# Altium schematic sheet AudioWayDSP-Power.SchDoc
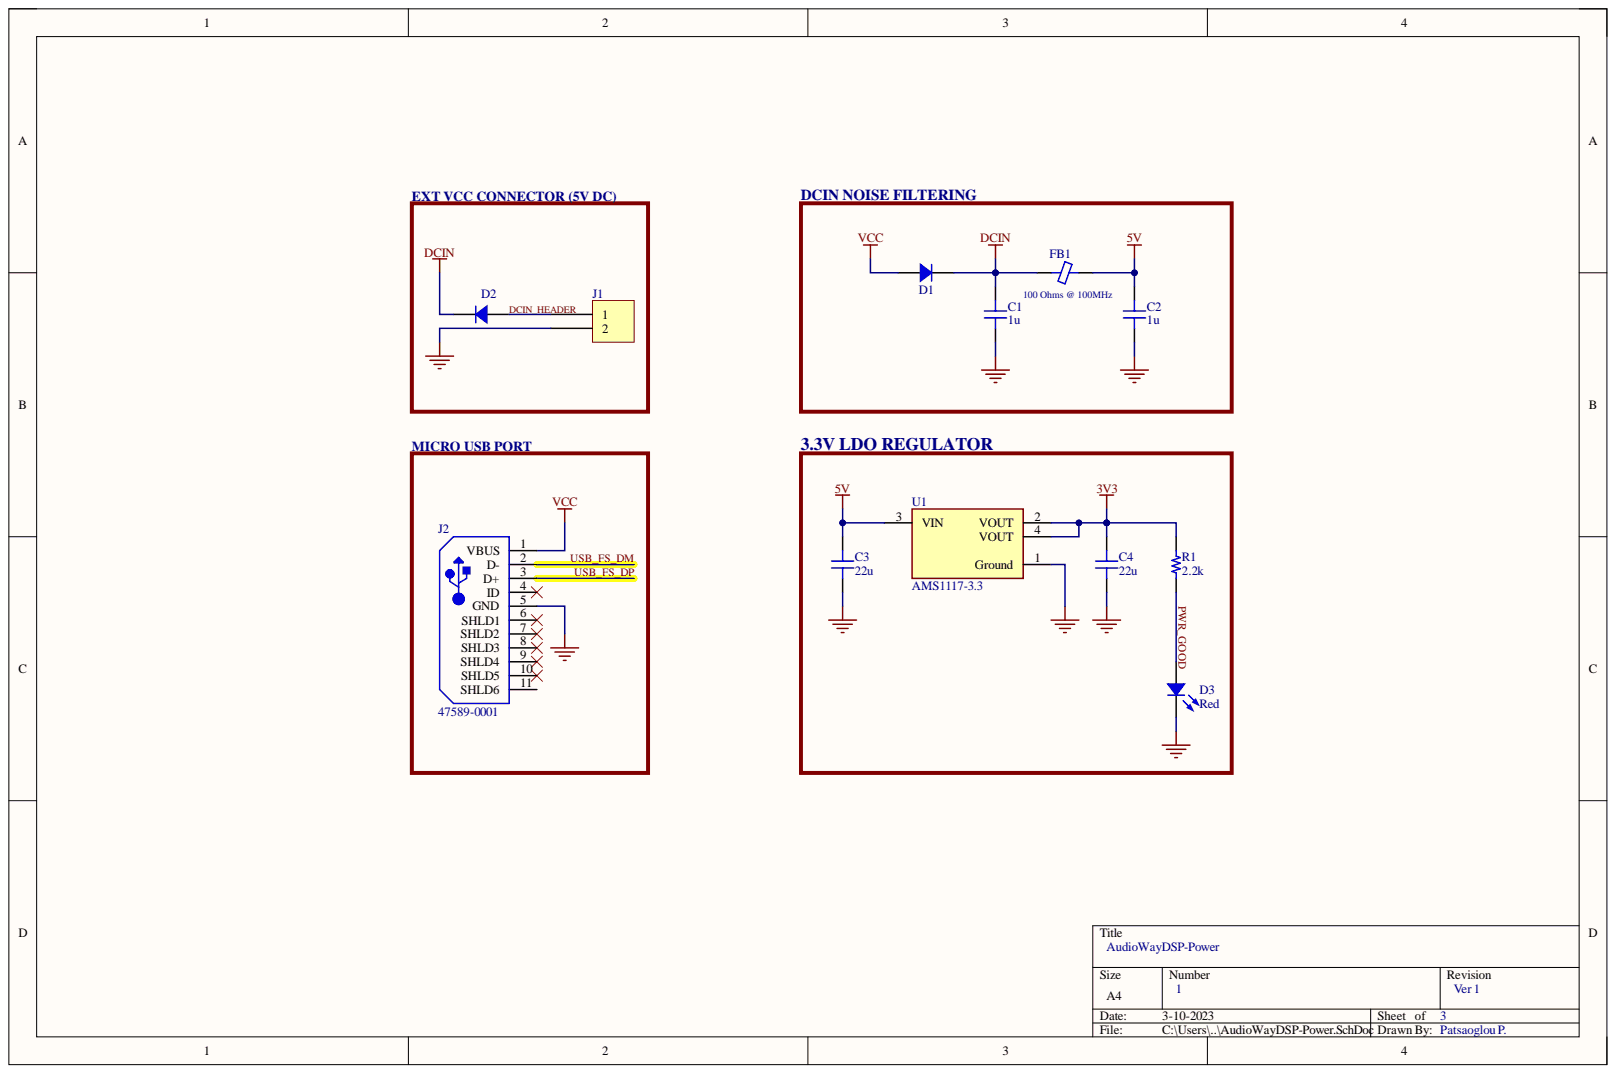
[diagram p0: AudioWayDSP-Power.SchDoc]

## Parts
C1  1u  [CAP 0603_1608]
C2  1u  [CAP 0603_1608]
C3  22u  [CAP 0805_2012]
C4  22u  [CAP 0805_2012]
D1  PMEG4010BEA,115  [SOD323]  (value not drawn)
D2  PMEG4010BEA,115  [SOD323]  (value not drawn)
D3  Red  [LED 0603_1608 RED]
FB1  100 Ohms @ 100MHz  [FER 0805_2012]
J1  B2B-XH-A(LF)(SN)  [JST XH B2B-XH-A]  (value not drawn)
J2  47589-0001  [MOLX-47589-0001_V]
R1  2.2k  [RES 0402_1005]
U1  AMS1117-3.3  [FP-AMS1117-IPC_C]

## Nets
3V3: C4.2 R1.1 U1.2(VOUT) U1.4(VOUT)
5V: C2.2 C3.2 FB1.2 U1.3(VIN)
DCIN: C1.2 D1.2 D2.2 FB1.1
GND: C1.1 C2.1 C3.1 C4.1 D3.2 J1.2 J2.5(GND) U1.1(Ground)
VCC: D1.1 J2.1(VBUS)
DCIN_HEADER: D2.1 J1.1
PWR_GOOD: D3.1 R1.2
USB_FS_DM: J2.2(D-)
USB_FS_DP: J2.3(D+)

Not connected: J2 4(ID) 6(SHLD1) 7(SHLD2) 8(SHLD3) 9(SHLD4) 10(SHLD5) 11(SHLD6)

## Library data of the parts
C1 C2 = 06035D105KAT2A: CAP CER 1UF 50V X5R 0603; manufacturer: AVX Corporation; Capacitance: 1µF; Database Table Name: Capacitors - Ceramic - 0603; Tolerance: ±10%; Applications: General Purpose; Component Kind: Standard; componentlink1description: Datasheet; componentlink1url: http://datasheets.avx.com/cx5r.pdf; componentlink2description: DigiKey Link; componentlink2url: http://digikey.com/product-detail/en/avx-corporation/06035D105KAT2A/478-10067-1-ND/6564289; Device Package: 0603 (1608 Metric); lastupdated: 02-Sep-20 1:03:26 AM; Library Name: Celestial Altium Library - altium_library.DbLib; Lifecycle Status: Active; Minimum Order: 1; Mounting Type: Surface Mount, MLCC; Operating Temperature: -55°C ~ 85°C; Package / Case: 0603 (1608 Metric); packaging: TapeAndReel; Part Number: 06035D105KAT2A; Part Status: Active; partid: 7730; price: 0.15; series: -; Size / Dimension: 0.063" L x 0.032" W (1.60mm x 0.81mm); Supplier 1: DigiKey; Supplier Part Number 1: 478-10067-1-ND; Temperature Coefficient: X5R; Thickness (Max): 0.035" (0.90mm); Value: 1u; Voltage - Rated: 50V
C3 C4 = TMK212BBJ226MG-TT: CAP CER 22UF 25V X5R 0805; manufacturer: Taiyo Yuden; Capacitance: 22 µF; Database Table Name: Capacitors - Ceramic - 0805; Tolerance: ±20%; Applications: SMPS Filtering; Component Kind: Standard; componentlink1description: Datasheet; componentlink1url: https://ds.yuden.co.jp/TYCOMPAS/ut/detail?pn=TMK212BBJ226MG-TT &u=M; componentlink2description: DigiKey Link; componentlink2url: https://www.digikey.com/en/products/detail/taiyo-yuden/TMK212BBJ226MG-TT/7403956; Device Package: 0805 (2012 Metric); lastupdated: 01-Mar-23 8:10:58 PM; Library Name: Celestial Altium Library - altium_library.DbLib; Lifecycle Status: Active; Minimum Order: 1; Mounting Type: Surface Mount, MLCC; Operating Temperature: -55°C ~ 85°C; Package / Case: 0805 (2012 Metric); packaging: TapeAndReel; Part Number: TMK212BBJ226MG-TT; Part Status: Active; partid: 8285; price: 0.16; series: M; Size / Dimension: 0.079" L x 0.049" W (2.00mm x 1.25mm); Supplier 1: DigiKey; Supplier Part Number 1: 587-6359-1-ND; Temperature Coefficient: X5R; Thickness (Max): 0.057" (1.45mm); Value: 22u; Voltage - Rated: 25V
D1 D2 = PMEG4010BEA,115: DIODE SCHOTTKY 40V 1A SOD323; Manufacturer: Nexperia USA Inc.; Capacitance @ Vr, F: 50pF @ 1V, 1MHz; Current - Reverse Leakage @ Vr: 100 µA @ 40 V; Database Table Name: Diodes - Rectifiers - Single; Speed: Fast Recovery =< 500ns, > 200mA (Io); Voltage - Forward (Vf) (Max) @ If: 640 mV @ 1 A; Component Kind: Standard; ComponentLink1Description: Datasheet; ComponentLink1URL: https://assets.nexperia.com/documents/data-sheet/PMEGXX10BEA_PMEGXX10BEV.pdf; ComponentLink2Description: DigiKey Link; ComponentLink2URL: https://www.digikey.com/en/products/detail/nexperia-usa-inc/PMEG4010BEA-115/1157708; Current - Average Rectified (Io): 1A; Diode Type: Schottky; LastUpdated: 01-Mar-23 9:08:18 PM; Library Name: Celestial Altium Library - altium_library.DbLib; Lifecycle Status: Active; Minimum Order: 1; Mounting Type: Surface Mount; Operating Temperature - Junction: 150°C (Max); Package / Case: SC-76, SOD-323; Packaging: TapeAndReel; Part Number: PMEG4010BEA,115; Part Status: Active; PartId: 3088; Price: 0.07; Series: -; Supplier 1: DigiKey; Supplier Device Package: SOD-323; Supplier Part Number 1: 1727-5211-1-ND; Voltage - DC Reverse (Vr) (Max): 40 V
D3 = 5973005507F: LED RED 0603 SMD; Manufacturer: Dialight; Configuration: Standard; Current - Test: 20mA; Database Table Name: LED - Indication; Lens Color: 0.68mm; Lens Size: 1.20mm x 0.80mm; Lens Style: Rectangle with Flat Top; Millicandela Rating: 90mcd; Voltage - Forward (Vf) (Typ): 2V; Wavelength - Dominant: 630nm; Color: Red; Component Kind: Standard; ComponentLink1Description: Datasheet; ComponentLink1URL: http://www.dialightsignalsandcomponents.com/Assets/Drawings/2D_Drawings_DrawingDetailedSpec/NewDrawings/18369A.pdf; ComponentLink2Description: DigiKey Link; ComponentLink2URL: https://www.digikey.com/en/products/detail/dialight/5973005507F/9385429; Device Package: 0603 (1608 Metric); Height (Max): 0.68mm; LastUpdated: 01-Mar-23 8:56:29 PM; Library Name: Celestial Altium Library - altium_library.DbLib; Lifecycle Status: Active; Minimum Order: 1; Mounting Type: Surface Mount; Package / Case: 0603 (1608 Metric); Packaging: TapeAndReel; Part Number: 5973005507F; Part Status: Active; PartId: 378; Price: 0.08; Series: 597; Size / Dimension: 1.60mm L x 0.80mm W; Supplier 1: DigiKey; Supplier Device Package: 0603; Supplier Part Number 1: 350-4374-1-ND; Value: Red
FB1 = Z0805C101ASMST: FERRITE BEAD 100 OHM 0805 1LN; Manufacturer: KEMET; Current Rating (Max): 900mA; Database Table Name: Filters - Ferrite Beads and Chips; DC Resistance (DCR) (Max): 100mOhm; Filter Type: Signal Line; Impedance @ Frequency: 600 Ohms @ 100MHz; Number of Lines: 1; Component Kind: Standard; ComponentLink1Description: Datasheet; ComponentLink1URL: https://content.kemet.com/datasheets/F3115.pdf; ComponentLink2Description: DigiKey Link; ComponentLink2URL: http://digikey.com/product-detail/en/kemet/Z0805C101ASMST/399-17791-1-ND/8601454; Device Package: 0805 (2012 Metric); Height (Max): 0.041" (1.05mm); LastUpdated: 01-Mar-23 8:50:40 PM; Library Name: Celestial Altium Library - altium_library.DbLib; Lifecycle Status: Active; Minimum Order: 1; Mounting Type: Surface Mount; Operating Temperature: -55°C ~ 125°C; Package / Case: 0805 (2012 Metric); Packaging: TapeAndReel; Part Number: Z0805C101ASMST; Part Status: Active; PartId: 1274; Price: 0.04; Series: Z-SMS; Size / Dimension: 0.079" L x 0.049" W (2.00mm x 1.25mm); Supplier 1: DigiKey; Supplier Part Number 1: 399-17791-1-ND; Value: 100 Ohms @ 100MHz
J1 = B2B-XH-A(LF)(SN): CONN HEADER VERT 2POS 2.5MM; Manufacturer: JST Sales America Inc.; Contact Length - Post: 0.134" (3.40mm); Current Rating (Amps): 3A; Database Table Name: Connectors - Rectangular Headers Male Pins; Material Flammability Rating: UL94 V-0; Number of Positions: 2; Number of Positions Loaded: All; Pitch - Mating: 0.098" (2.50mm); Shrouding: Shrouded - 4 Wall; Style: Board to Cable/Wire; Voltage Rating: 250V; Component Kind: Standard; ComponentLink1Description: Datasheet; ComponentLink1URL: http://www.jst-mfg.com/product/pdf/eng/eXH.pdf; ComponentLink2Description: DigiKey Link; ComponentLink2URL: http://digikey.com/product-detail/en/jst-sales-america-inc/B2B-XH-A-LF-SN/455-2247-ND/1651045; Connector Type: Header; Contact Finish - Mating: Tin; Contact Finish - Post: Tin; Contact Material: Brass; Contact Shape: Square; Contact Type: Male Pin; Fastening Type: Detent Lock; Insulation Color: Natural; Insulation Height: 0.276" (7.00mm); Insulation Material: Polyamide (PA66), Nylon 6/6; LastUpdated: 12-Jan-20 11:46:08 PM; Library Name: Celestial Altium Library - altium_library.DbLib; Lifecycle Status: Active; Minimum Order: 1; Mounting Type: Through Hole; Number of Rows: 1; Operating Temperature: -25°C ~ 85°C; Packaging: Bulk; Part Number: B2B-XH-A(LF)(SN); Part Status: Active; PartId: 67; Price: 0.15; Series: XH; Supplier 1: DigiKey; Supplier Part Number 1: 455-2247-ND; Termination: Press-Fit, Solder
J2 = CMP-2000-05610-1: Right Angle Female Type AB Micro USB Connector, 30 V, 1.8 A, -30 to 85 degC, RoHS, Tape and Reel; Brand: Molex; Connector Type: MicroUSBTypeABreceptacle; Current Rating: 1.8A; Number of Contacts: 5Contact; Number of Ports: 1Port; Voltage Rating: 30VAC; Color: Black; Component Kind: Standard; Contact Material: CopperAlloy; Contact Plating: Gold; Datasheet URL: http://www.molex.com/webdocs/datasheets/pdf/en-us/0475890001_IO_CONNECTORS.pdf; Datasheet Version: 12/2016; Gender: Female; Library Name: Altium Content Vault; Manufacturer: Molex; Manufacturer URL: http://www.molex.com/; Mounting Angle: Right; Mounting Technology: SurfaceMount; Operating Temperature Range: -20Cto+85C; Package Description: Micro USB Connector, Pitch 0.65 mm, 5 Positions, Body 7.5 x 5.6 mm; Package Reference: 47589-0001; Package URL: http://www.molex.com/pdm_docs/sd/475890001_sd.pdf; Packaging: Reel; Product: MicroUSBTypeABConnectors; Product Category: USBConnectors; RoHS: True; Series: 47589; Standard: USB; Termination Style: SMD/SMT
R1 = ERA-2VRW2201X: RES 2.2K OHM 0.05% 1/10W 0402; Manufacturer: Panasonic Electronic Components; Database Table Name: Resistors - Surface Mount - 0402; Power (Watts): 0.1W, 1/10W; Resistance: 2.2 kOhms; Temperature Coefficient: ±10ppm/°C; Component Kind: Standard; ComponentLink1Description: Datasheet; ComponentLink1URL: https://b2b-api.panasonic.eu/file_stream/pids/fileversion/9152; ComponentLink2Description: DigiKey Link; ComponentLink2URL: https://www.digikey.com/en/products/detail/panasonic-electronic-components/ERA-2VRW2201X/12087785; Composition: Thin Film; Device Package: 0402 (1005 Metric); Features: Anti-Sulfur, Automotive AEC-Q200; Height - Seated (Max): 0.016" (0.40mm); LastUpdated: 01-Mar-23 8:20:02 PM; Library Name: Celestial Altium Library - altium_library.DbLib; Lifecycle Status: Active; Minimum Order: 1; Number of Terminations: 2; Operating Temperature: -55°C ~ 155°C; Package / Case: 0402 (1005 Metric); Packaging: TapeAndReel; Part Number: ERA-2VRW2201X; Part Status: Active; PartId: 60215; Price: 0.24; Ratings: AEC-Q200; Series: ERA-2V; Size / Dimension: 0.039" L x 0.020" W (1.00mm x 0.50mm); Supplier 1: DigiKey; Supplier Device Package: 0402; Supplier Part Number 1: 10-ERA-2VRW2201XCT-ND; Tolerance: ±0.05%; Value: 2.2k
U1 = CMP-209535-000001-1: LDO Voltage Regulators 1A, 3.3V; Manufacturer: Advanced Monolithic Systems; Dropout Voltage: 1.1V; Max Junction Temp: +125°C; Max Power Dissipation: 1.2W; Max Supply Voltage: 15V; Nominal Supply Current: 5mA; Number Of Outputs: 1; Output Type: Fixed; Standoff Height: 0.64mm; Automotive: No; Category: IC; Component Kind: Standard; ComponentLink2Description: Datasheet; ComponentLink2URL: http://www.advanced-monolithic.com/pdf/ds1117.pdf; Device Class L1: Integrated Circuits (ICs); Device Class L2: Power Management ICs; Device Class L3: Voltage Regulators - Linear; Height: 1.8mm; Ipc Land Pattern Name: SOT229P700X180-4; Lead Free: Yes; Library Name: Altium Content Vault; Manufacturer Part Number: AMS1117-3.3; Octopart: https://octopart.com/ams1117-3.3-advanced+monolithic+systems-25899300; Output Current: 1A; Output Voltage: 3.3V; Package: AMS1117; RoHS: TRUE; Temperature: -40°C to +125°C; Temperature Range High: +125°C; Temperature Range Low: -40°C
